# Altium schematic sheet Power_and_Regulator V1.0.SchDoc("Power_and_Regulator V1.0")
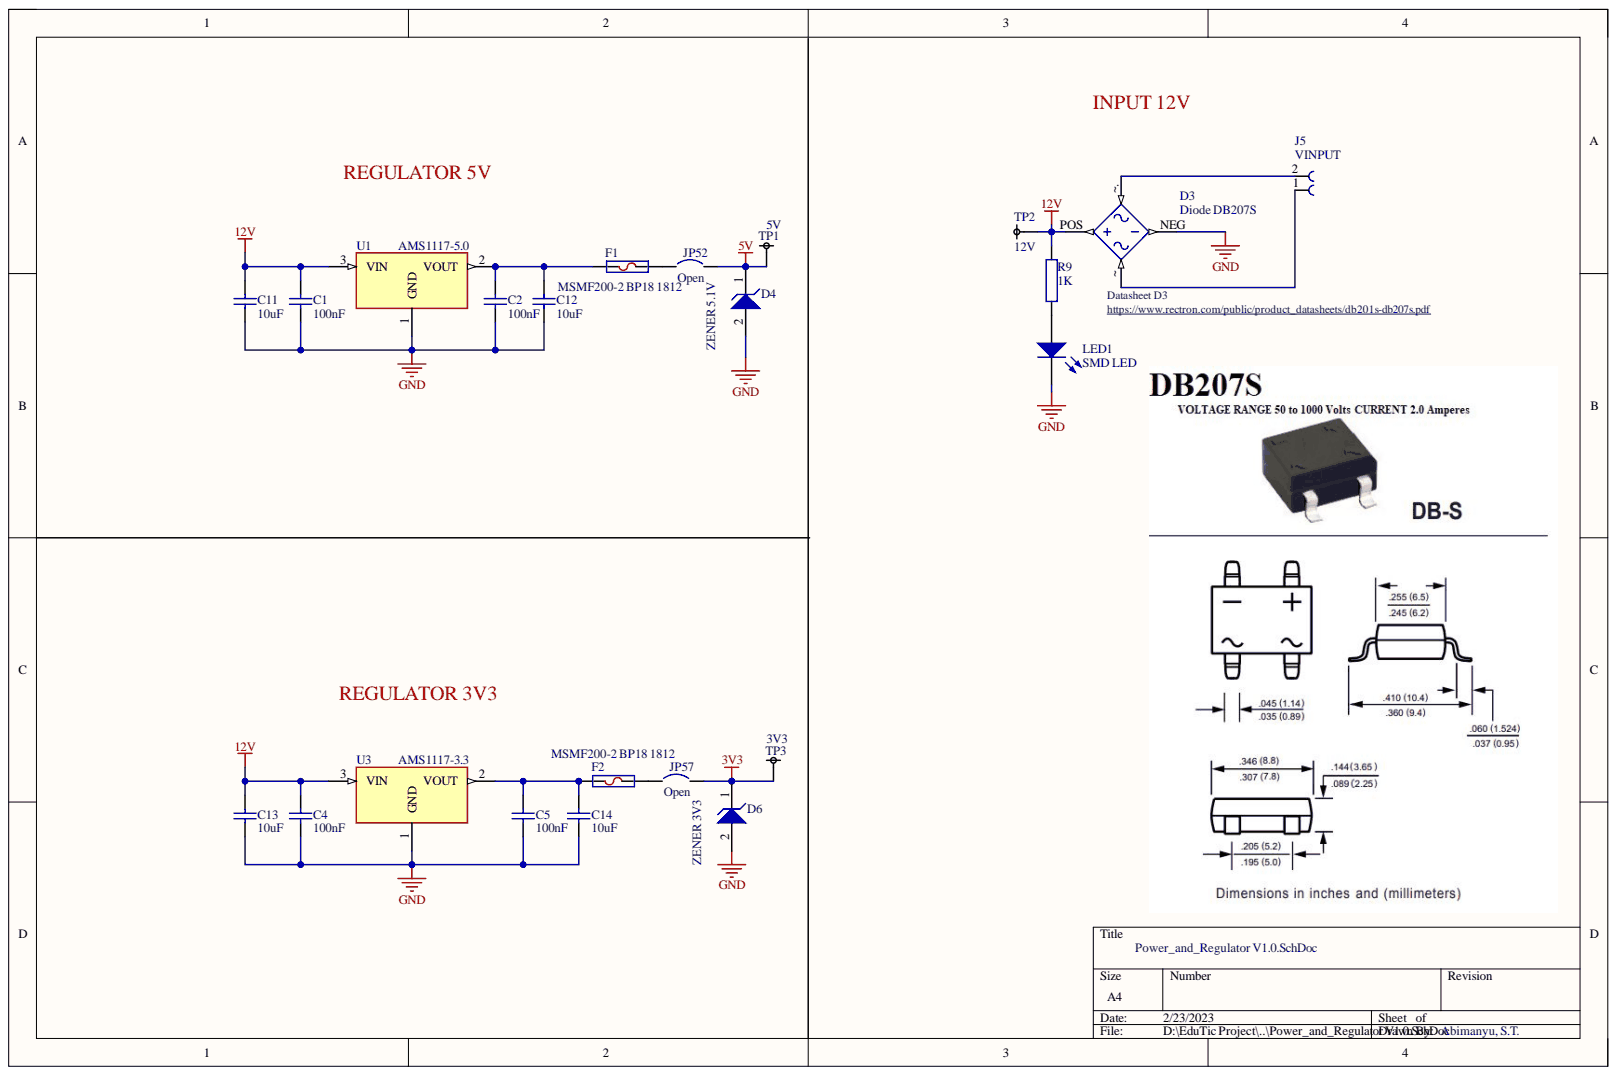
[diagram p0: Power_and_Regulator V1.0.SchDoc]

## Parts
C1  100nF  [1608_[0603] Capacitor]
C2  100nF  [1608_[0603] Capacitor]
C4  100nF  [1608_[0603] Capacitor]
C5  100nF  [1608_[0603] Capacitor]
C11  10uF  [1608_[0603] Capacitor]
C12  10uF  [1608_[0603] Capacitor]
C13  10uF  [1608_[0603] Capacitor]
C14  10uF  [1608_[0603] Capacitor]
D3  Diode DB207S  [SOP 4 - Dioda Bridge - duplicate]
D4  ZENER 5.1V  [SOD-80C]
D6  ZENER 3V3  [SOD-80C]
F1  value MSMF200-2 BP18 1812  [1206_Fuse]  (hidden comment: 1210)
F2  value MSMF200-2 BP18 1812  [1206_Fuse]  (hidden comment: 1210)
J5  VINPUT  [TERMINAL BLOCK PLUG 2P 5MM]
JP52  Open  [SB_STM]
JP57  Open  [SB_STM]
LED1  SMD LED  [LED SMT 1608 (0603)]
R9  1K  [1608_[0603] Resistor]
TP1  5V  [PAD]
TP2  12V  [PAD]
TP3  3V3  [PAD]
U1  AMS1117-5.0  [SOT-223]
U3  AMS1117-3.3  [SOT-223]

## Nets
3V3: D6.1 JP57.2 TP3.1
5V: D4.1 JP52.2 TP1.1
12V: C1.2 C4.2 C11.2 C13.2 D3.POS R9.2 TP2.1 U1.3 U3.3
GND: C1.1 C2.1 C4.1 C5.1 C11.1 C12.1 C13.1 C14.1 D3.NEG D4.2 D6.2 LED1.2 U1.1 U3.1
NetC2_2: C2.2 C12.2 F1.1 U1.2
NetC5_2: C5.2 C14.2 F2.1 U3.2
NetD3_~: D3.~ D3.~. J5.1 J5.2
NetF1_2: F1.2 JP52.1
NetF2_2: F2.2 JP57.1
NetLED1_1: LED1.1 R9.1

## Library data of the parts
C1 C2 C4 C5 C11-C14 = Capacitor 0805 (Inch): 0805 SMD Non-Polarity Capacitor; Component Kind: Standard; Library Name: AB_Lib.SchLib
D3 = Diode DB207S: Bridge Rectifier Single Phase Standard 1kV 2A Surface Mount DB-S; MF: SMC Diode; MP: DB107S; Availability: Unavailable; Component Kind: Standard; Library Name: KS_ALT_V1.SchLib; Package: SMD-4 SMC Diode; Price: None; SnapEDA_Link: https://www.snapeda.com/parts/DB107S/SMC%20Diode%20Solutions/view-part/582848/?ref=snap
D4 D6 = Diode Zener 2: Zener Diodes 4.7V 200mW; PN: BZT55C4V7-GS08; Component Kind: Standard; Library Name: KS_ALT.SchLib
F1 F2 = PTC RESET FUSE: PTC RESET FUSE 8V 2A; Value: MSMF200-2 BP18 1812; Component Kind: Standard; LatestRevisionDate: 17-Jul-2002; LatestRevisionNote: Re-released for DXP Platform.; Library Name: KS_ALT.SchLib; PackageDescription: Thru-Hole; 2 Leads; PackageReference: PIN-W2/E2.8; Published: 8-Jun-2000; Simulation: FUSE; Publisher: Altium Limited
J5 = TERMINAL BLOCK PLUG 2P 5MM: 2 Position Terminal Block Header, Male Pins, Shrouded (2 Side) 0.197 (5.00mm) 90Â°, Right Angle - Through Hole; MF: Wurth Elektronik; MP: 691312710002; Availability: In Stock; Check_prices: https://www.snapeda.com/parts/691312710002/Wurth+Elektronik/view-part/?ref=eda; Component Kind: Standard; Library Name: AB_Lib.SchLib; Package: None; Price: None; Purchase-URL: https://pricing.snapeda.com/search/part/691312710002/?ref=eda; SnapEDA_Link: https://www.snapeda.com/parts/691312710002/Wurth+Elektronik/view-part/?ref=snap
JP52 JP57 = Jumper Solder: Jumper Solder Pad; Component Kind: Standard; Library Name: AB_Lib.SchLib; Published: 24-Mar-1999; Revision: July-2002: Re-released for DXP Platform.; Publisher: Altium Limited
LED1 = LED SMT RED: 0603 RED LED; Component Kind: Standard; LatestRevisionDate: 08-Jul-2005; LatestRevisionNote: Mech Layer 1 removed.; Library Name: AB_Lib.SchLib; PackageDescription: LED; 2 Leads; PackageReference: LED-0; Published: 8-Jun-2000; Publisher: Altium Limited
R9 = Resistor 0805 (Inch): 0805 SMD Thick Resistor; Component Kind: Standard; Library Name: AB_Lib.SchLib
TP1-TP3 = Test Point: Thick Pad; Component Kind: Standard; Library Name: AB_Lib.SchLib
U3 = AMS1117-3.3: MP: AMS1117-5.0; Availability: Unavailable; Component Kind: Standard; Library Name: AB_Lib.SchLib; Package: None; Price: None
U1 = AMS1117-5.0: Availability: Unavailable; Component Kind: Standard; Library Name: AB_Lib.SchLib; MP: AMS1117-5.0; Package: None; Price: None
